# Altium schematic sheet 11_ATK-DLMP257_PCIE2.0X1_IO.SchDoc
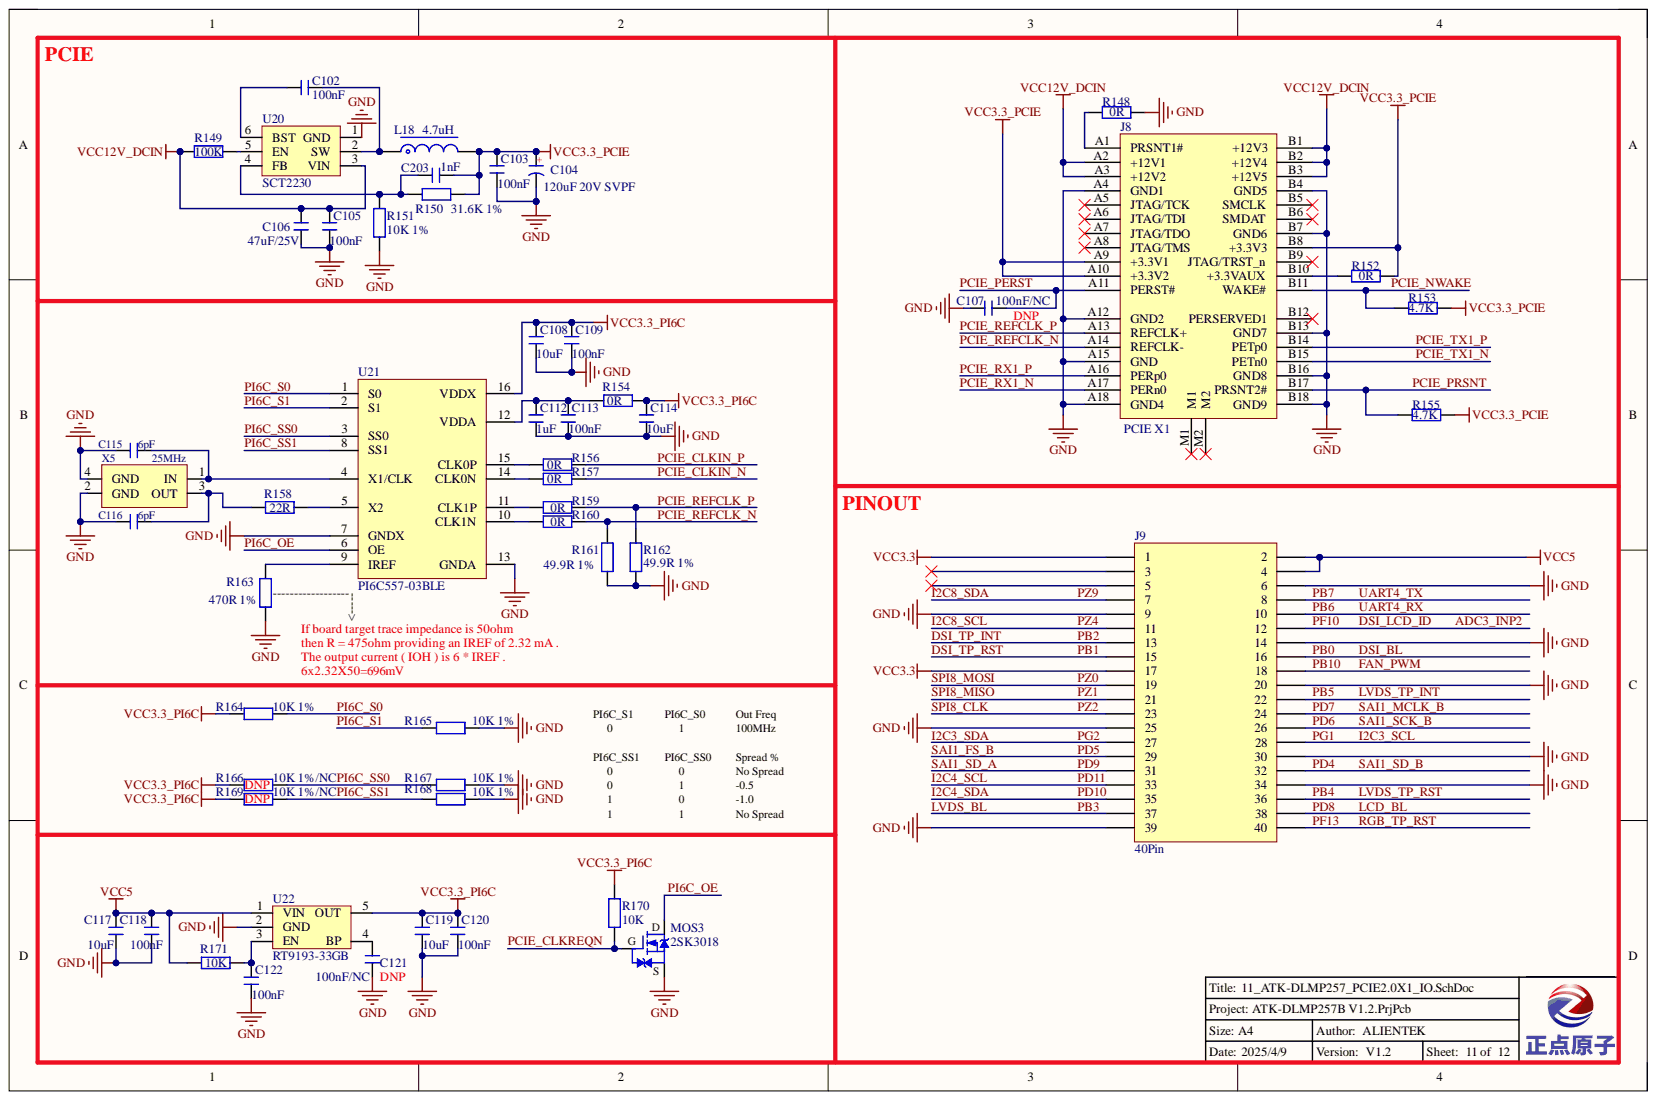
[diagram p0: 11_ATK-DLMP257_PCIE2.0X1_IO.SchDoc]

## Parts
C102  100nF  [C 0603]
C103  100nF  [C 0603]
C104  120uF 20V SVPF  [CM D(6.3*7.7)]
C105  100nF  [C 0603]
C106  47uF/25V  [C 1206]
C107  100nF/NC  [C 0603]
C108  10uF  [C 0603]
C109  100nF  [C 0603]
C112  1uF  [C 0603]
C113  100nF  [C 0603]
C114  10uF  [C 0603]
C115  6pF  [C 0603]
C116  6pF  [C 0603]
C117  10uF  [C 0603]
C118  100nF  [C 0603]
C119  10uF  [C 0603]
C120  100nF  [C 0603]
C121  100nF/NC  [C 0603]
C122  100nF  [C 0603]
C203  1nF  [C 0603]
J8  PCIE X1  [PCIEX1]
J9  40Pin  [HDR-20X2]
L18  4.7uH  [L-SMD-0420]
MOS3  2SK3018  [SOT323-3L]
R148  0R  [R 0603]
R149  100K  [R 0603]
R150  31.6K 1%  [R 0603]
R151  10K 1%  [R 0603]
R152  0R  [R 0603]
R153  4.7K  [R 0603]
R154  0R  [R 0603]
R155  4.7K  [R 0603]
R156  0R  [R 0603]
R157  0R  [R 0603]
R158  22R  [R 0603]
R159  0R  [R 0603]
R160  0R  [R 0603]
R161  49.9R 1%  [R 0603]
R162  49.9R 1%  [R 0603]
R163  470R 1%  [R 0603]
R164  10K 1%  [R 0603]
R165  10K 1%  [R 0603]
R166  10K 1%/NC  [R 0603]
R167  10K 1%  [R 0603]
R168  10K 1%  [R 0603]
R169  10K 1%/NC  [R 0603]
R170  10K  [R 0603]
R171  10K  [R 0603]
U20  SCT2230  [SOT23-6N]
U21  PI6C557-03BLE  [TSSOP-16]
U22  RT9193-33GB  [SOT23-5N]
X5  25MHz  [XTAL-3225]

## Nets
GND: C103.2 C104.2 C105.1 C106.1 C107.1 C108.1 C109.1 C112.1 C113.1 C114.1 C115.2 C116.2 C117.1 C118.1 C119.1 C120.1 C121.1 C122.1 J8.A4(GND1) J8.A12(GND2) J8.A15(GND) J8.A18(GND4) J8.B4(GND5) J8.B7(GND6) J8.B13(GND7) J8.B16(GND8) J8.B18(GND9) J9.6 J9.9 J9.14 J9.20 J9.25 J9.30 J9.34 J9.39 MOS3.2 R148.2 R151.2 R161.2 R162.2 R163.1 R165.2 R167.2 R168.2 U20.1(GND) U21.7(GNDX) U21.13(GNDA) U22.2(GND) X5.2(GND) X5.4(GND)
VCC3.3: J9.1 J9.17
VCC3.3_PCIE: C103.1 C104.1 C203.1 J8.A9(+3.3V1) J8.A10(+3.3V2) J8.B8(+3.3V3) L18.2 R150.2 R152.2 R153.2 R155.2
VCC3.3_PI6C: C108.2 C109.2 C114.2 C119.2 C120.2 R154.1 R164.1 R166.1 R169.1 R170.2 U21.16(VDDX) U22.5(OUT)
VCC5: C117.2 C118.2 J9.2 J9.4 R171.2 U22.1(VIN)
VCC12V_DCIN: C105.2 C106.2 J8.A2(+12V1) J8.A3(+12V2) J8.B1(+12V3) J8.B2(+12V4) J8.B3(+12V5) R149.1 U20.3(VIN)
ADC3_INP2: J9.12
DSI_BL: J9.16
DSI_TP_INT: J9.13
DSI_TP_RST: J9.15
FAN_PWM: J9.18
I2C3_SCL: J9.28
I2C3_SDA: J9.27
I2C4_SCL: J9.33
I2C4_SDA: J9.35
I2C8_SCL: J9.11
I2C8_SDA: J9.7
LCD_BL: J9.38
LVDS_BL: J9.37
LVDS_TP_INT: J9.22
LVDS_TP_RST: J9.36
PB6: J9.10
PB7: J9.8
PCIE_CLKIN_N: R157.1
PCIE_CLKIN_P: R156.1
PCIE_CLKREQN: MOS3.1 R170.1
PCIE_NWAKE: J8.B11(WAKE#) R153.1
PCIE_PERST: C107.2 J8.A11(PERST#)
PCIE_PRSNT: J8.B17(PRSNT2#) R155.1
PCIE_REFCLK_N: J8.A14(REFCLK-) R160.1 R161.1
PCIE_REFCLK_P: J8.A13(REFCLK+) R159.1 R162.1
PCIE_RX1_N: J8.A17(PERn0)
PCIE_RX1_P: J8.A16(PERp0)
PCIE_TX1_N: J8.B15(PETn0)
PCIE_TX1_P: J8.B14(PETp0)
PD4: J9.32
PD5: J9.29
PD6: J9.26
PD7: J9.24
PD9: J9.31
PF13: J9.40
PI6C_OE: MOS3.3 U21.6(OE)
PI6C_S0: R164.2 U21.1(S0)
PI6C_S1: R165.1 U21.2(S1)
PI6C_SS0: R166.2 R167.1 U21.3(SS0)
PI6C_SS1: R168.1 R169.2 U21.8(SS1)
PZ0: J9.19
PZ1: J9.21
PZ2: J9.23
NetC102_1: C102.1 U20.6(BST)
NetC102_2: C102.2 L18.1 U20.2(SW)
NetC112_2: C112.2 C113.2 R154.2 U21.12(VDDA)
NetC115_1: C115.1 U21.4(X1/CLK) X5.1(IN)
NetC116_1: C116.1 R158.1 X5.3(OUT)
NetC121_2: C121.2 U22.4(BP)
NetC122_2: C122.2 R171.1 U22.3(EN)
NetC203_2: C203.2 R150.1 R151.1 U20.4(FB)
NetJ8_A1: J8.A1(PRSNT1#) R148.1
NetJ8_B10: J8.B10(+3.3VAUX) R152.1
NetR149_2: R149.2 U20.5(EN)
NetR156_2: R156.2 U21.15(CLK0P)
NetR157_2: R157.2 U21.14(CLK0N)
NetR158_2: R158.2 U21.5(X2)
NetR159_2: R159.2 U21.11(CLK1P)
NetR160_2: R160.2 U21.10(CLK1N)
NetR163_2: R163.2 U21.9(IREF)

Not connected: J8 A5(JTAG/TCK) A6(JTAG/TDI) A7(JTAG/TDO) A8(JTAG/TMS) B5(SMCLK) B6(SMDAT) B9(JTAG/TRST_n) B12(PERSERVED1) M1 M2; J9 3 5

## Library data of the parts
C102 C103 C105-C109 C112-C122 C203 = C: 无极性贴片电容; 版权归属: 源创客; BOM名称: 无极性贴片电容; Component Kind: Standard; 学习资源: www.ooocke.com
  C102 C103 C105-C109 C112-C114 C117-C122 C203: Library Name: 电阻-电容-电感.SchLib
  C115 C116: Library Name: Lycreturn.IntLib
C104 = C-CM: 贴片电解电容; 版权归属: 源创客; BOM名称: 贴片电解电容; Component Kind: Standard; Library Name: 电阻-电容-电感.SchLib; 学习资源: www.ooocke.com
J8 = PCIEX1: Component Kind: Standard; Library Name: ZZK_COMPONENT.IntLib
J9 = RPI40Pin: Component Kind: Standard; Library Name: ATK-DLMP257B_V0.3.SCHLIB
L18 = L-CDRH: 功率屏蔽电感; 版权归属: 源创客; BOM名称: 功率屏蔽电感; Component Kind: Standard; Library Name: 电阻-电容-电感.SchLib; 学习资源: www.ooocke.com
MOS3 = 2SK3018: NMOS  30V 0.1A@2.5V 8Ω; BOM名称: N沟场效应管; Parameter: MOS管; 版权归属: 正点原子; Component Kind: Standard; 学习资源: www.openedv.com; Library Name: Lycreturn.IntLib
R148 R152-R171 = R: 贴片电阻; 版权归属: 正点原子; BOM名称: 贴片电阻; Component Kind: Standard; 学习资源: www.openedv.com; Library Name: Lycreturn.IntLib
R149-R151 = R: 贴片电阻; 版权归属: 源创客; BOM名称: 贴片电阻; Component Kind: Standard; Library Name: 电阻-电容-电感.SchLib; 学习资源: www.ooocke.com
U20 = DC JW5060T_6: DC降压芯片; Component Kind: Standard; Library Name: LDO和DCDC.SchLib
U22 = RT9193: LDO 5.5V 300mA; BOM名称: 稳压芯片; Parameter: 芯片; 版权归属: 正点原子; Component Kind: Standard; 学习资源: www.openedv.com; Library Name: Lycreturn.IntLib
X5 = XTAL-4P: 4脚无源晶振; BOM名称: 4脚晶振; 版权归属: 源创客; Component Kind: Standard; 学习资源: www.ooocke.com; Library Name: Lycreturn.IntLib
U21 = PI6C557-03BLE: Component Kind: Standard; Library Name: ZZK_COMPONENT.IntLib
